# Altium schematic sheet LED.SchDoc
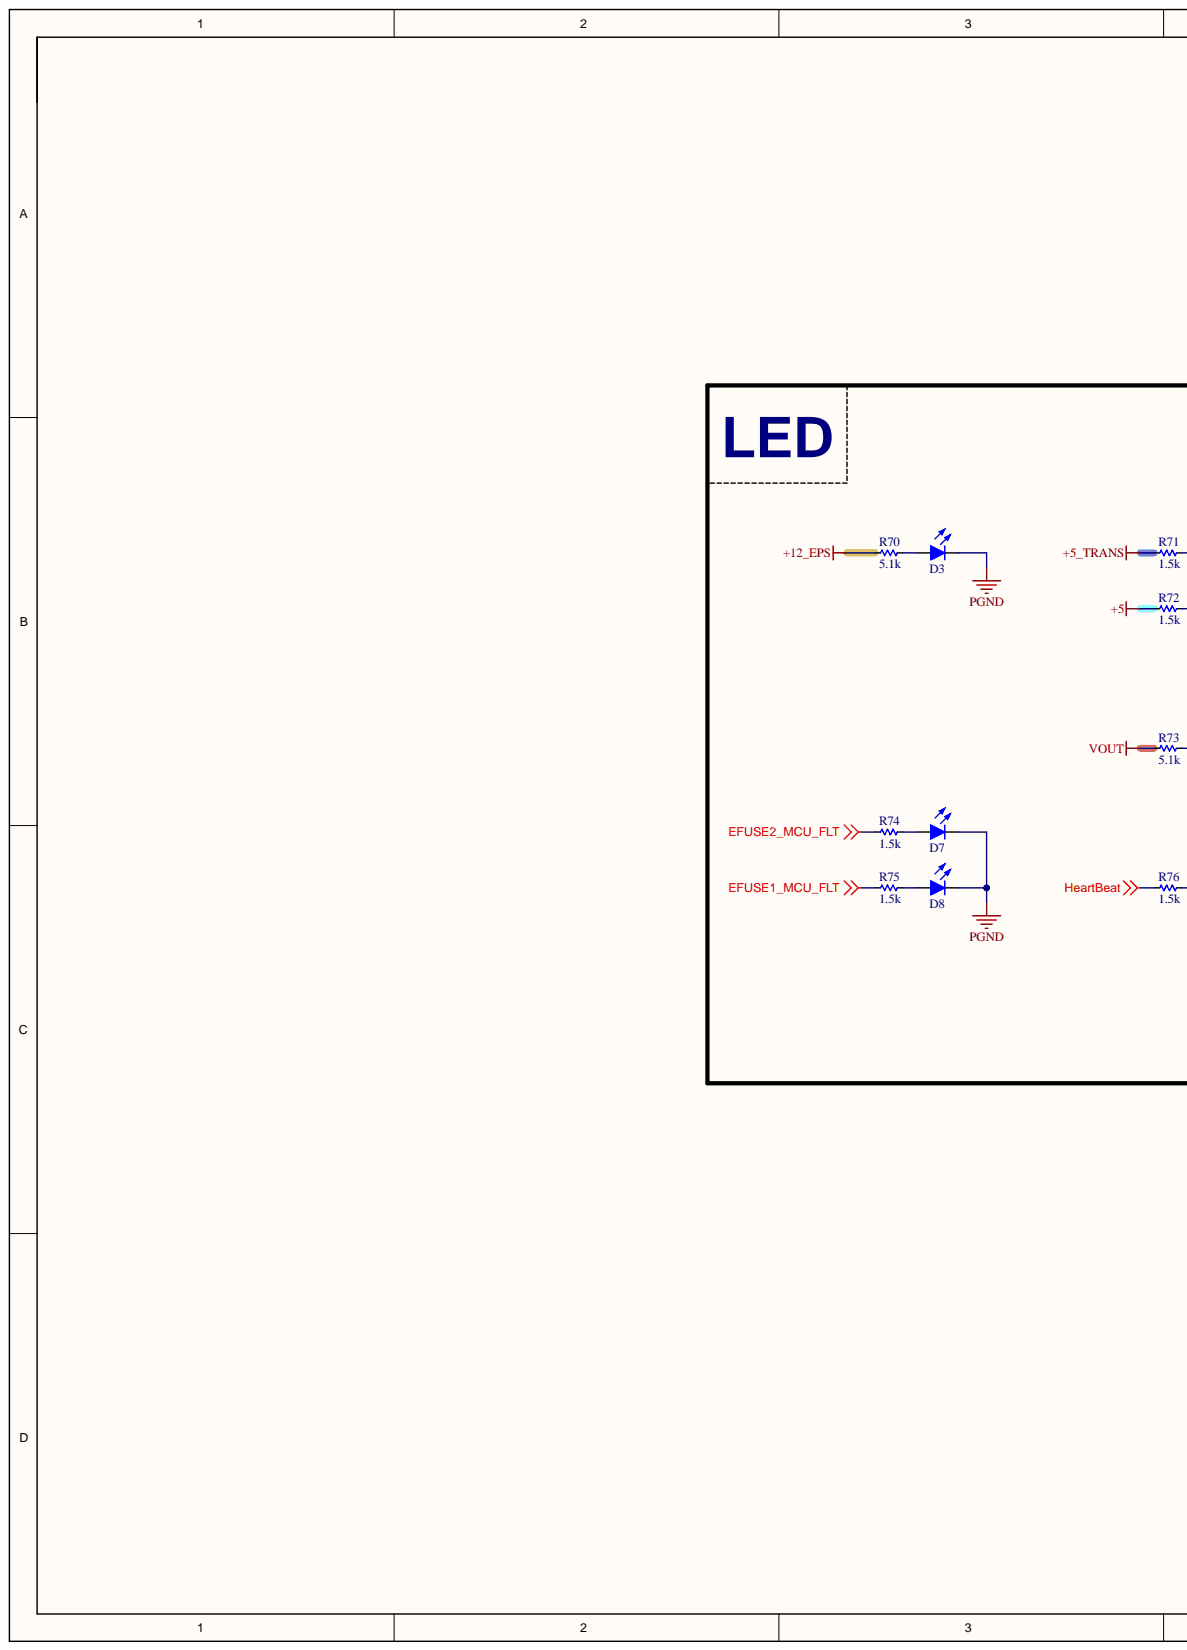
[diagram p0: LED.SchDoc, left]
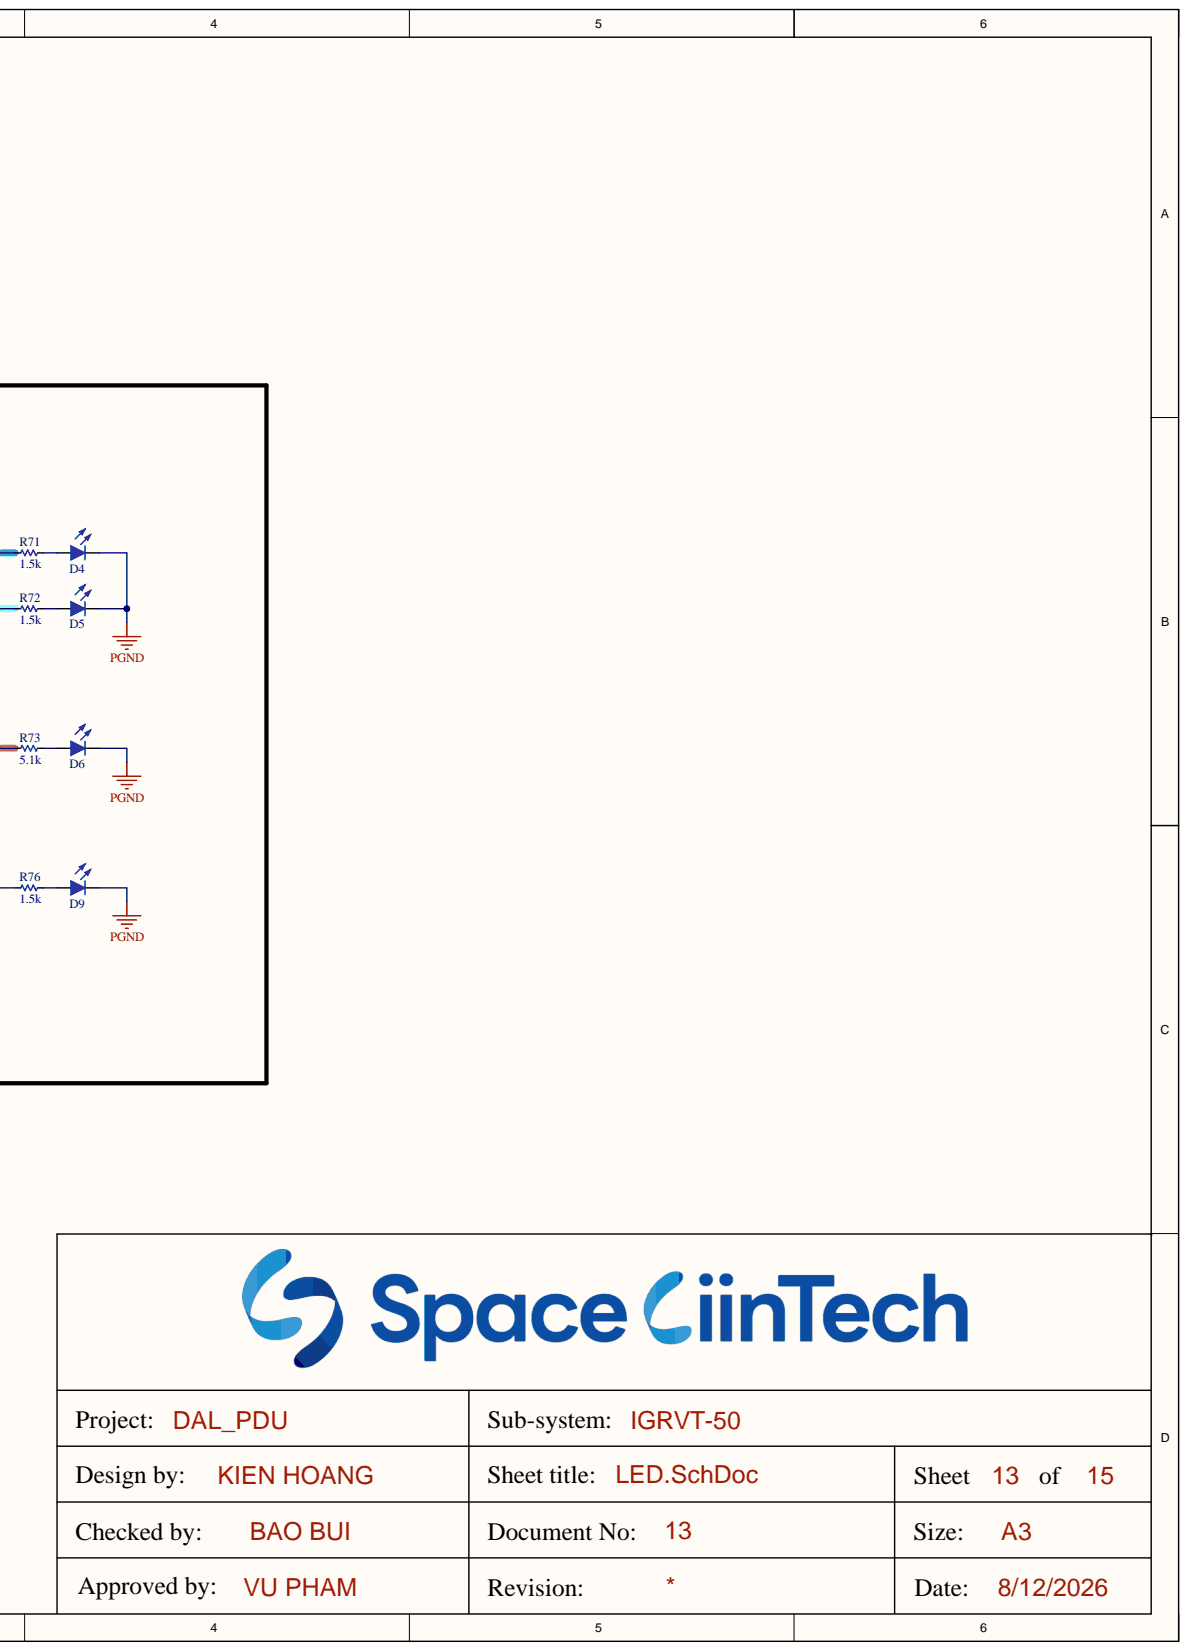
[diagram p1: LED.SchDoc, right]

## Parts
D3  Led  [Led 0603]  (value not drawn)
D4  Led  [Led 0603]  (value not drawn)
D5  Led  [Led 0603]  (value not drawn)
D6  Led  [Led 0603]  (value not drawn)
D7  Led  [Led 0603]  (value not drawn)
D8  Led  [Led 0603]  (value not drawn)
D9  Led  [Led 0603]  (value not drawn)
R70  5.1k  [RES_C_1608X60]
R71  1.5k  [RES_C_1608X60]
R72  1.5k  [RES_C_1608X60]
R73  5.1k  [RES_C_1608X60]
R74  1.5k  [RES_C_1608X60]
R75  1.5k  [RES_C_1608X60]
R76  1.5k  [RES_C_1608X60]

## Nets
+5: R72.2
+5_TRANS: R71.2
+12_EPS: R70.2
EFUSE1_MCU_FLT: R75.2
EFUSE2_MCU_FLT: R74.2
HeartBeat: R76.2
PGND: D3.2 D4.2 D5.2 D6.2 D7.2 D8.2 D9.2
VOUT: R73.2
NetD3_1: D3.1 R70.1
NetD4_1: D4.1 R71.1
NetD5_1: D5.1 R72.1
NetD6_1: D6.1 R73.1
NetD7_1: D7.1 R74.1
NetD8_1: D8.1 R75.1
NetD9_1: D9.1 R76.1

## Library data of the parts
D3-D9 = Led: Typical LED; Component Kind: Standard; Library Name: KIENASIY123_LIB.IntLib
R70-R76 = _RES_B_2_2: RES; Component Kind: Standard; Library Name: LABLIB.SchLib
